# Altium schematic sheet KWATCH_DEV_V0.1.SchDoc(KWATCH_DEV_V0.1)
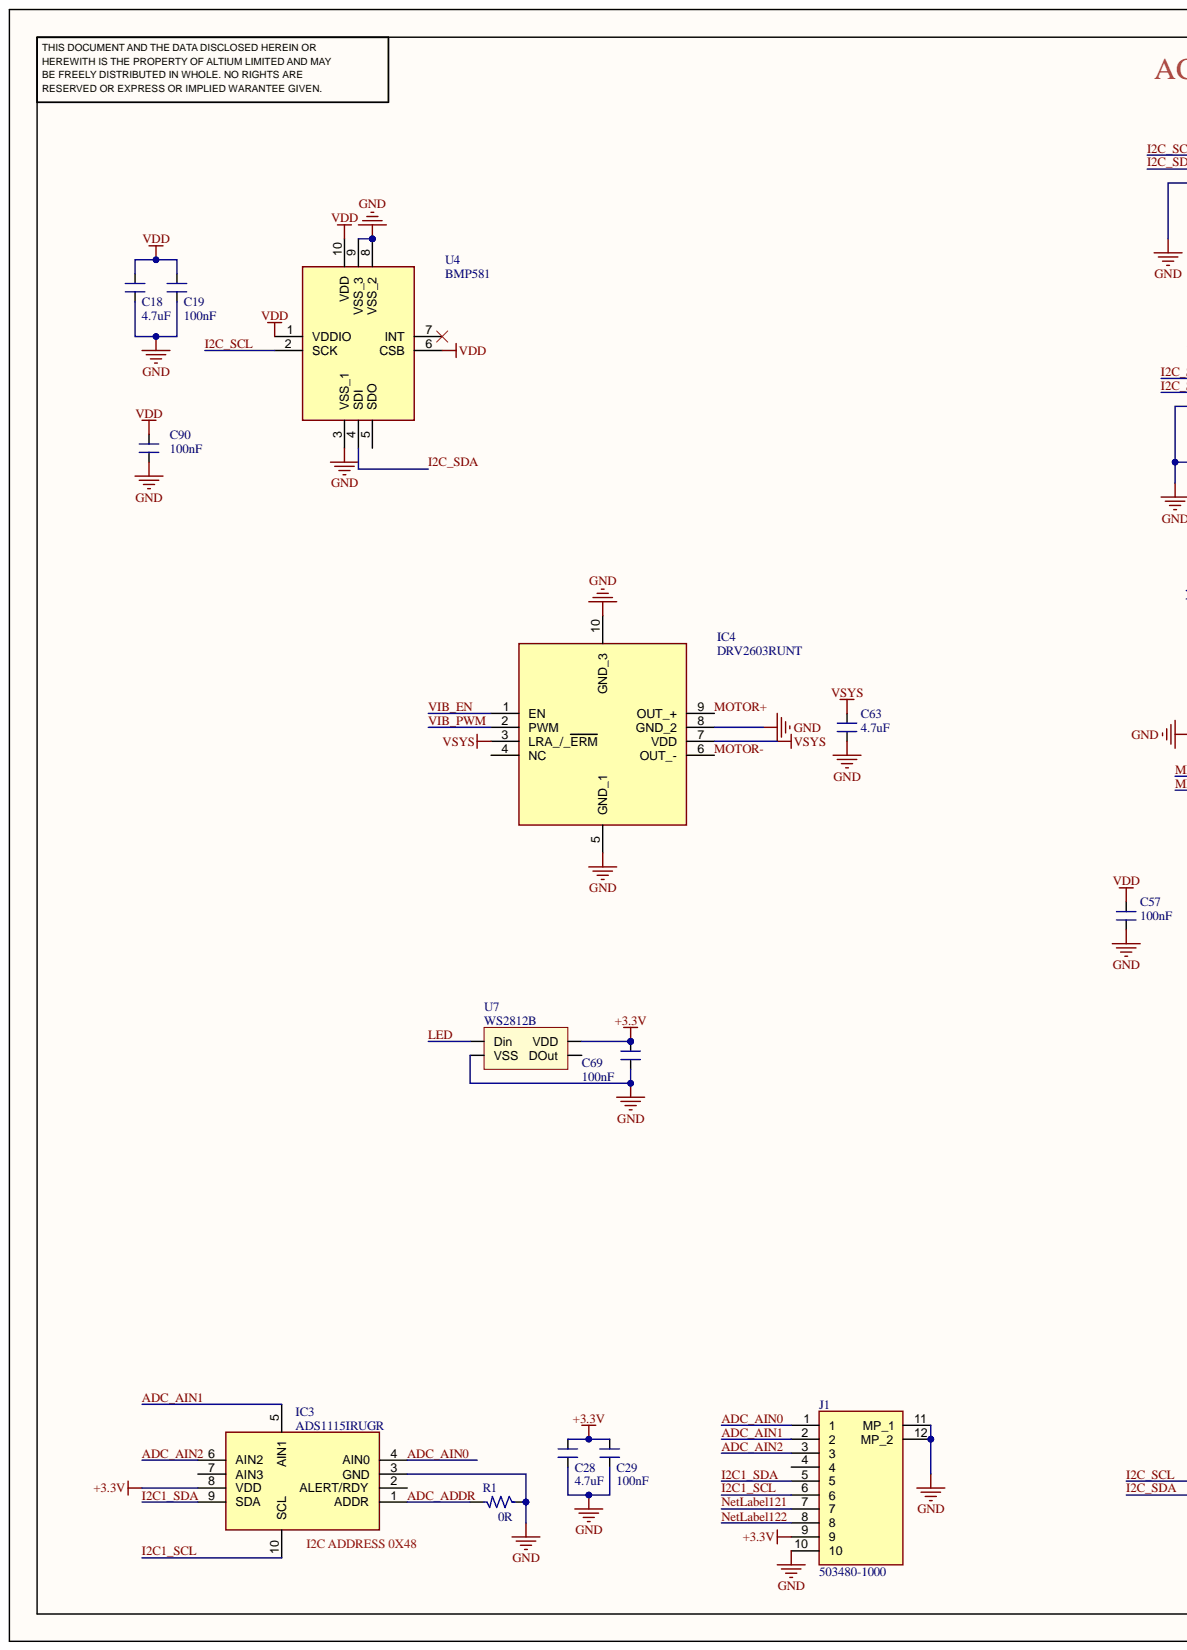
[diagram p0: KWATCH_DEV_V0.1.SchDoc, left]
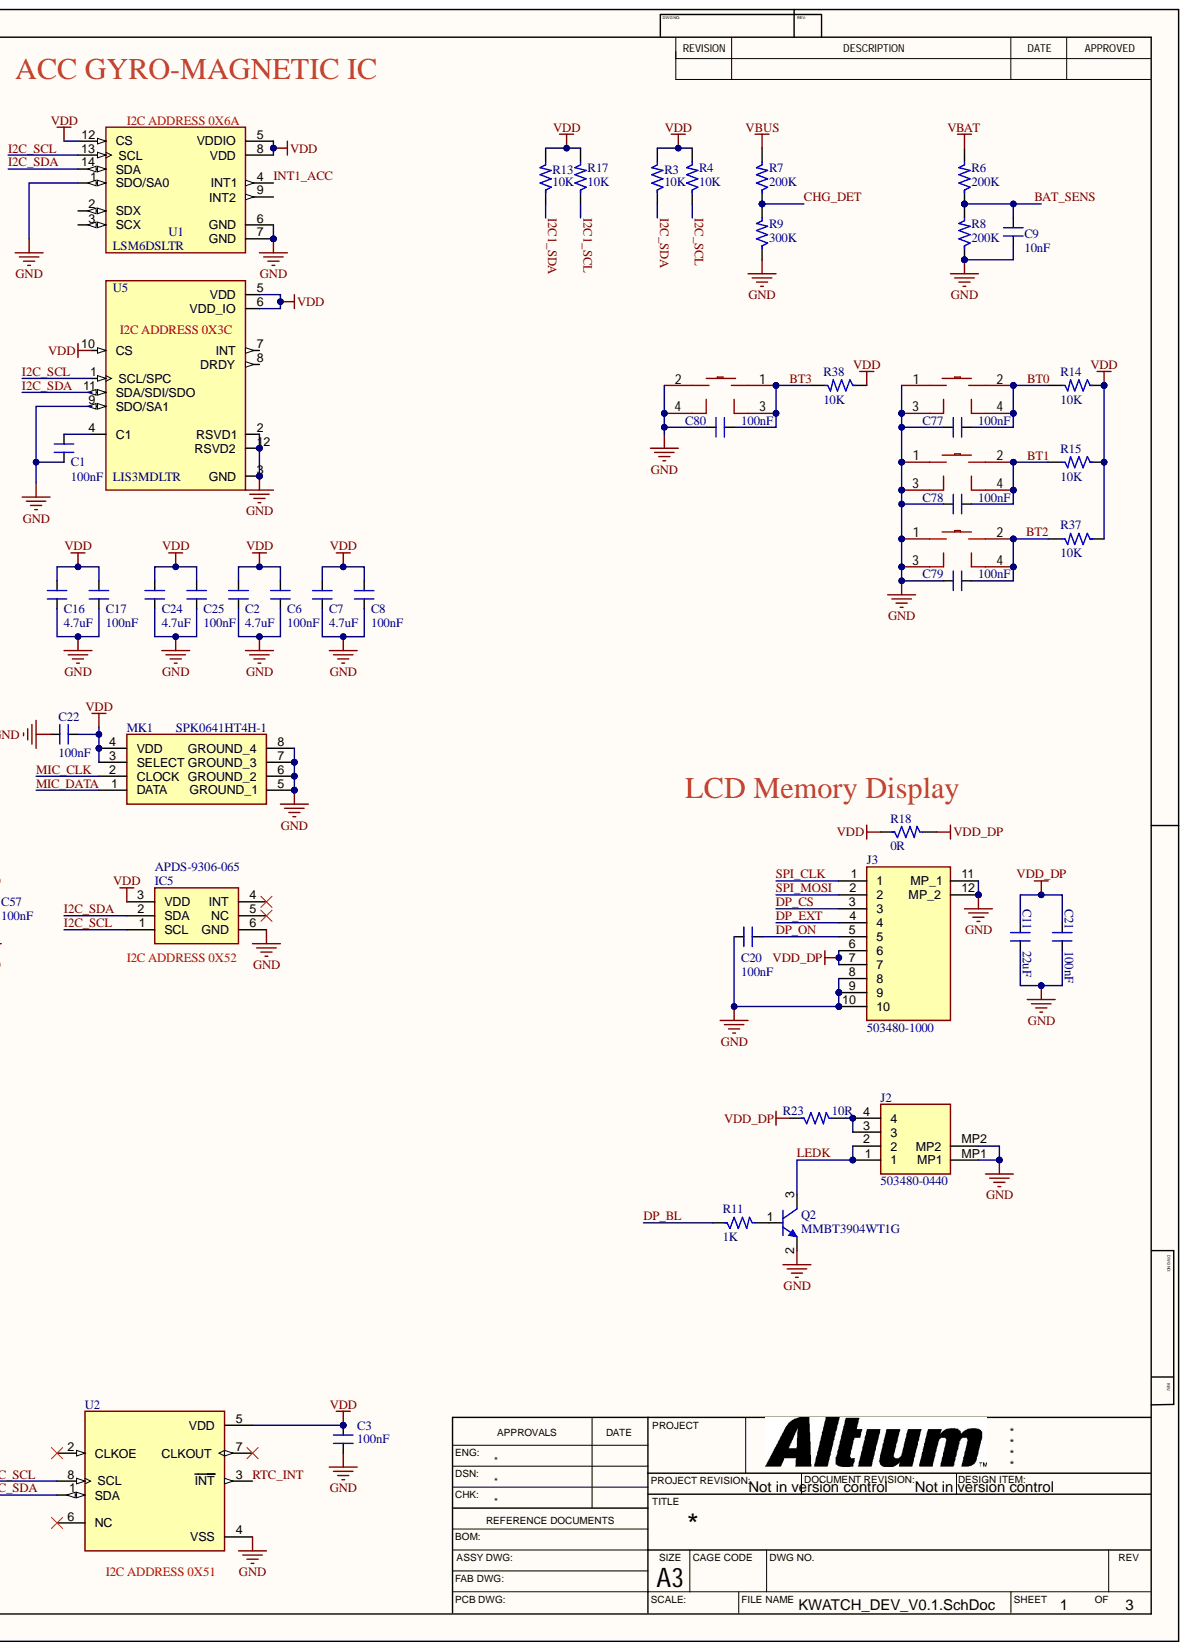
[diagram p1: KWATCH_DEV_V0.1.SchDoc, right]

## Parts
C1  100nF  [CAP_0402]
C2  4.7uF  [CAP_0402]
C3  100nF  [CAP_0402]
C6  100nF  [CAP_0402]
C7  4.7uF  [CAP_0402]
C8  100nF  [CAP_0402]
C9  10nF  [CAP_0402]
C11  22uF  [CAP_0402]
C16  4.7uF  [CAP_0402]
C17  100nF  [CAP_0402]
C18  4.7uF  [CAP_0402]
C19  100nF  [CAP_0402]
C20  100nF  [CAP_0402]
C21  100nF  [CAP_0402]
C22  100nF  [CAP_0402]
C24  4.7uF  [CAP_0402]
C25  100nF  [CAP_0402]
C28  4.7uF  [CAP_0402]
C29  100nF  [CAP_0402]
C57  100nF  [CAP_0402]
C63  4.7uF  [CAP_0402]
C69  100nF  [CAP_0402]
C77  100nF  [CAP_0402]
C78  100nF  [CAP_0402]
C79  100nF  [CAP_0402]
C80  100nF  [CAP_0402]
C90  100nF  [CAP_0402]
IC3  ADS1115IRUGR  [ADS1115IRUGR]
IC4  DRV2603RUNT  [QFN50P200X200X80-10N-D]
IC5  APDS-9306-065  [APDS9306065]
J1  503480-1000  [5034801000]
J2  503480-0440  [5034800440]
J3  503480-1000  [5034801000]
MK1  SPK0641HT4H-1  [SPK0641HT4H1]
Q2  MMBT3904WT1G  [SOT-323-3]
R1  0R  [RES_0402]
R3  10K  [RES_0402]
R4  10K  [RES_0402]
R6  200K  [RES_0402]
R7  200K  [RES_0402]
R8  200K  [RES_0402]
R9  300K  [RES_0402]
R11  1K  [RES_0402]
R13  10K  [RES_0402]
R14  10K  [RES_0402]
R15  10K  [RES_0402]
R17  10K  [RES_0402]
R18  0R  [RES_0402]
R23  10R  [RES_0402]
R37  10K  [RES_0402]
R38  10K  [RES_0402]
SW1  T1C2QR  [KEY-SMD_T1C2QR]  (value not drawn)
SW2  T1C2QR  [KEY-SMD_T1C2QR]  (value not drawn)
SW3  T1C2QR  [KEY-SMD_T1C2QR]  (value not drawn)
SW4  T1C2QR  [KEY-SMD_T1C2QR]  (value not drawn)
U1  LSM6DSLTR  [PQFN50P300X250X86-14N]
U2  RV-8263-C8-32.768KHZ-20PPM-TA-QC  [IC_RV-8263-C8-32.768KHZ-20PPM-TA-QC]  (value not drawn)
U4  BMP581  [BMP581]
U5  LIS3MDLTR  [XDCR_LIS3MDLTR]
U7  WS2812B  [WS2812-2020]

## Nets
+3.3V: C28.2 C29.2 C69.2 IC3.8(VDD) J1.9 U7.1(VDD)
GND: C1.1 C2.1 C3.1 C6.1 C7.1 C8.1 C9.1 C11.1 C16.1 C17.1 C18.1 C19.1 C20.2 C21.1 C22.1 C24.1 C25.1 C28.1 C29.1 C57.1 C63.1 C69.1 C77.1 C78.1 C79.1 C80.1 C90.1 IC3.3(GND) IC4.5(GND_1) IC4.8(GND_2) IC4.10(GND_3) IC5.6(GND) J1.10 J1.11(MP_1) J1.12(MP_2) J2.MP1 J2.MP2 J3.8 J3.9 J3.10 J3.11(MP_1) J3.12(MP_2) MK1.5(GROUND_1) MK1.6(GROUND_2) MK1.7(GROUND_3) MK1.8(GROUND_4) Q2.2 R1.1 R8.1 R9.1 SW1.1 SW1.3 SW2.1 SW2.3 SW3.1 SW3.3 SW4.2 SW4.4 U1.1(SDO/SA0) U1.6(GND) U1.7(GND) U2.4(VSS) U4.3(VSS_1) U4.8(VSS_2) U4.9(VSS_3) U5.2(RSVD1) U5.3(GND) U5.9(SDO/SA1) U5.12(RSVD2) U7.3(VSS)
VBAT: R6.2
VBUS: R7.2
VDD: C2.2 C3.2 C6.2 C7.2 C8.2 C16.2 C17.2 C18.2 C19.2 C22.2 C24.2 C25.2 C57.2 C90.2 IC5.3(VDD) MK1.3(SELECT) MK1.4(VDD) R3.2 R4.2 R13.2 R14.1 R15.1 R17.2 R18.1 R37.1 R38.1 U1.5(VDDIO) U1.8(VDD) U1.12(CS) U2.5(VDD) U4.1(VDDIO) U4.6(CSB) U4.10(VDD) U5.5(VDD) U5.6(VDD_IO) U5.10(CS)
VDD_DP: C11.2 C21.2 J3.6 J3.7 R18.2 R23.1
VSYS: C63.2 IC4.3(LRA_/_ERM) IC4.7(VDD)
ADC_ADDR: IC3.1(ADDR) R1.2
ADC_AIN0: IC3.4(AIN0) J1.1
ADC_AIN1: IC3.5(AIN1) J1.2
ADC_AIN2: IC3.6(AIN2) J1.3
BAT_SENS: C9.2 R6.1 R8.2
BT0: C77.2 R14.2 SW1.2 SW1.4
BT1: C78.2 R15.2 SW2.2 SW2.4
BT2: C79.2 R37.2 SW3.2 SW3.4
BT3: C80.2 R38.2 SW4.1 SW4.3
CHG_DET: R7.1 R9.2
DP_BL: R11.1
DP_CS: J3.3
DP_EXT: J3.4
DP_ON: C20.1 J3.5
I2C1_SCL: IC3.10(SCL) J1.6 R17.1
I2C1_SDA: IC3.9(SDA) J1.5 R13.1
I2C_SCL: IC5.1(SCL) R4.1 U1.13(SCL) U2.8(SCL) U4.2(SCK) U5.1(SCL/SPC)
I2C_SDA: IC5.2(SDA) R3.1 U1.14(SDA) U2.1(SDA) U4.4(SDI) U5.11(SDA/SDI/SDO)
INT1_ACC: U1.4(INT1)
LED: U7.4(Din)
LEDK: J2.1 J2.2 Q2.3
MIC_CLK: MK1.2(CLOCK)
MIC_DATA: MK1.1(DATA)
MOTOR+: IC4.9(OUT_+)
MOTOR-: IC4.6(OUT_-)
NetLabel121: J1.7
NetLabel122: J1.8
RTC_INT: U2.3(INT)
SPI_CLK: J3.1
SPI_MOSI: J3.2
VIB_EN: IC4.1(EN)
VIB_PWM: IC4.2(PWM)
NetC1_2: C1.2 U5.4(C1)
NetJ2_3: J2.3 J2.4 R23.2
NetQ2_1: Q2.1 R11.2

Not connected: IC3 2(ALERT/RDY) 7(AIN3); IC4 4(NC); IC5 4(INT) 5(NC); J1 4; U1 2(SDX) 3(SCX) 9(INT2); U2 2(CLKOE) 6(NC) 7(CLKOUT); U4 5(SDO) 7(INT); U5 7(INT) 8(DRDY); U7 2(DOut)

## Library data of the parts
C1 C3 C6 C8 C17 C19 C22 C25 C29 C57 C69 C77-C80 C90 = CAP_100nF-10V-10%-0402: 0.1µF ±10% 10V Ceramic Capacitor X7R 0402 (1005 Metric); Manufacturer: Yageo; Manufacturer Part Number: CC0402KRX7R6BB104; Case/Package: 0402; Component Kind: Standard; Supplier-1: Digikey; Library Name: EsteemPCB_SOM_Course_schlib.SchLib
C2 C7 C16 C18 C24 C28 C63 = CAP_4.7uF-10V-10%-0402: 4.7µF ±10% 10V Ceramic Capacitor X5R 0402 (1005 Metric); Manufacturer: Samsung Electro-Mechanics; Manufacturer Part Number: CL05A475KP5NRNC; Case/Package: 0402; Component Kind: Standard; Supplier-1: Digikey; Library Name: EsteemPCB_SOM_Course_schlib.SchLib
C9 = CAP_10nF-10V-10%-0402: 10000pF ±10% 10V Ceramic Capacitor X7R 0402 (1005 Metric); Manufacturer: AVX Corporation; Manufacturer Part Number: 0402ZC103KAT2A; Case/Package: 0402; Component Kind: Standard; Supplier-1: Digikey; Library Name: EsteemPCB_SOM_Course_schlib.SchLib
C11 = CAP_22uF-6.3V-20%-0402: 22µF ±20% 6.3V Ceramic Capacitor X5R 0402 (1005 Metric); Manufacturer: Murata Electronics; Manufacturer Part Number: CL05A226MQ5N6J8; Case/Package: 0402; Component Kind: Standard; Supplier-1: Digikey; Library Name: EsteemPCB_SOM_Course_schlib.SchLib
C20 C21 = CAP_100nF-25V-10%-0402: 0.1µF ±10% 25V Ceramic Capacitor X7R 0402 (1005 Metric); Manufacturer: Walsin Technology Corporation; Manufacturer Part Number: 0402B104K250CT; Case/Package: 0402; Component Kind: Standard; Supplier-1: Digikey; Library Name: EsteemPCB_SOM_Course_schlib.SchLib
IC3 = ADS1115IRUGR: Integrated Circuit; Manufacturer_Name: Texas Instruments; Arrow Part Number: ADS1115IRUGR; Arrow Price/Stock: https://www.arrow.com/en/products/ads1115irugr/texas-instruments?utm_currency=USD&region=nac; Component Kind: Standard; Datasheet Link: https://www.ti.com/lit/ds/symlink/ads1115.pdf?ts=1601235703022&ref_url=https%253A%252F%252Fwww.ti.com%252Fproduct%252FADS1115%253FkeyMatch%253DADS1115IRUGR%2526tisearch%253DSearch-EN-everything%2526usecase%253DOPN; Height: 0.4mm; Manufacturer_Part_Number: ADS1115IRUGR; Mouser Part Number: 595-ADS1115IRUGR; Mouser Price/Stock: https://www.mouser.co.uk/ProductDetail/Texas-Instruments/ADS1115IRUGR?qs=IK5e5L0zOXhqw%2F8AHSSbuA%3D%3D
IC4 = DRV2603RUNT: Integrated Circuit; Manufacturer_Name: Texas Instruments; Arrow Part Number: DRV2603RUNT; Arrow Price/Stock: https://www.arrow.com/en/products/drv2603runt/texas-instruments?utm_currency=USD&region=europe; Component Kind: Standard; Datasheet Link: http://www.ti.com/lit/ds/symlink/drv2603.pdf; Height: 0.8mm; Manufacturer_Part_Number: DRV2603RUNT; Mouser Part Number: 595-DRV2603RUNT; Mouser Price/Stock: https://www.mouser.co.uk/ProductDetail/Texas-Instruments/DRV2603RUNT?qs=y0LsnOHuQQ66fXxKAgGo4A%3D%3D
IC5 = APDS-9306-065: Integrated Circuit; Manufacturer_Name: Avago Technologies; Component Kind: Standard; Datasheet Link: A19870331a; Height: 0.75mm; Manufacturer_Part_Number: APDS-9306-065; Mouser Part Number: 630-APDS-9306-065; Mouser Price/Stock: https://www.mouser.co.uk/ProductDetail/Broadcom-Avago/APDS-9306-065?qs=JUmsgfbaopRTut8Cx4p19A%3D%3D
J1 J3 = 503480-1000: Connector; Manufacturer_Name: Molex; Component Kind: Standard; Datasheet Link: https://www.molex.com/pdm_docs/sd/5034801000_sd.pdf; Height: 2.07mm; Manufacturer_Part_Number: 503480-1000; Mouser Part Number: 538-503480-1000; Mouser Price/Stock: https://www.mouser.co.uk/ProductDetail/Molex/503480-1000?qs=bodV9ulq6Gwg5aYhFLiLRA%3D%3D
J2 = 503480-0440: Connector; Manufacturer_Name: Molex; Component Kind: Standard; Datasheet Link: https://www.molex.com/pdm_docs/sd/5034800440_sd.pdf; Height: 2.12mm; Manufacturer_Part_Number: 503480-0440; Mouser Part Number: 538-503480-0440; Mouser Price/Stock: https://www.mouser.co.uk/ProductDetail/Molex/503480-0440?qs=LBbezbu20sggwP%2FAt293Pw%3D%3D
MK1 = SPK0641HT4H-1: Microphone; Manufacturer_Name: Knowles Johanson Manufacturing; Component Kind: Standard; Datasheet Link: https://www.knowles.com/docs/default-source/model-downloads/spk0641ht4h-1-rev-a.pdf; Height: 1.1mm; Manufacturer_Part_Number: SPK0641HT4H-1; Mouser Part Number: 721-SPK0641HT4H-1; Mouser Price/Stock: https://www.mouser.com/Search/Refine.aspx?Keyword=721-SPK0641HT4H-1
Q2 = TRAN-NPN_40V-200mA-SOT323: Bipolar (BJT) Transistor NPN 40V 200mA 300MHz 150mW Surface Mount SC-70-3 (SOT323); Manufacturer: ON Semiconductor; Case/Package: SOT323; Component Kind: Standard; Manufacturer Part Number: MMBT3904WT1G; Supplier-1: Digikey; Library Name: EsteemPCB_SOM_Course_schlib.SchLib
R1 R18 = RES_0R-0.1W-1%-0402: 0 Ohms Jumper 0.1W, 1/10W Chip Resistor 0402 (1005 Metric) Automotive AEC-Q200 Thick Film; Manufacturer: Panasonic Electronic Components; Manufacturer Part Number: ERJ-2GE0R00X; Case/Package: 0402; Component Kind: Standard; Supplier-1: Digikey; Library Name: EsteemPCB_SOM_Course_schlib.SchLib
R3 R4 R13-R15 R17 R37 R38 = RES_10K-0.1W-1%-0402: 10 kOhms ±1% 0.1W, 1/10W Chip Resistor 0402 (1005 Metric) Anti-Sulfur Thin Film; Manufacturer: Vishay Beyschlag/Draloric/BC Components; Manufacturer Part Number: MCS04020C1002FE000; Case/Package: 0402; Component Kind: Standard; Supplier-1: Digikey; Library Name: EsteemPCB_SOM_Course_schlib.SchLib
R6-R8 = RES_200K-0.1W-1%-0402: 200 kOhms ±1% 0.1W, 1/10W Chip Resistor 0402 (1005 Metric) Automotive AEC-Q200 Thick Film; Manufacturer: Panasonic Electronic Components; Manufacturer Part number: ERJ-2RKF2003X; Case/Package: 0402; Component Kind: Standard; Supplier-1: Digikey; Library Name: EsteemPCB_SOM_Course_schlib.SchLib
R9 = RES_200K-0.1W-1%-0402: 300 kOhms ±1% 0.1W, 1/10W Chip Resistor 0402 (1005 Metric) Automotive AEC-Q200 Thick Film; Manufacturer: Panasonic Electronic Components; Manufacturer Part number: ERJ-2RKF2003X; Case/Package: 0402; Component Kind: Standard; Supplier-1: Digikey; Library Name: EsteemPCB_SOM_Course_schlib.SchLib
R11 = RES_1K-0.1W-1%-0402: 1 kOhms ±1% 0.1W, 1/10W Chip Resistor 0402 (1005 Metric) Anti-Sulfur Thin Film; Manufacturer: Vishay Beyschlag/Draloric/BC Components; Manufacturer Part Number: MCS04020C1001FE000; Case/Package: 0402; Component Kind: Standard; Supplier-1: Digikey; Library Name: EsteemPCB_SOM_Course_schlib.SchLib
R23 = RES_0.1R-0.1W-1%-0402: 10 Ohms ±1% 0.1W, 1/10W Chip Resistor 0402 (1005 Metric) Current Sense, Moisture Resistant Thick Film; Manufacturer: Samsung Electro-Mechanics; Manufacturer Part Number: RUT1005FR100CS; Casse/Package: 0402; Component Kind: Standard; Supplier-1: Digikey; Library Name: EsteemPCB_SOM_Course_schlib.SchLib
SW1-SW4 = T1C2QR: Manufacturer: Diptronics(圜达); Actuator Style: Round Button; Add into BOM: yes; Circuit: SPST; Contact Current: 20mA; Voltage Rating: 15V; Component Kind: Standard; Convert to PCB: yes; Datasheet: https://item.szlcsc.com/datasheet/T1C2QR/507368.html; Device: T1C2QR; JLCPCB Part Class: Extended Part; LCSC Part Name: 侧按贴片; Library Name: SamacSys.SchLib; Life: -; Manufacturer Part: T1C2QR; Mounting Type: Surface Mount, Right Angle; PCB3D: KEY-SMD_T1C2QR; Supplier: LCSC; Supplier Footprint: SMD,2x2.8mm; Supplier Part: C495948; Symbol: T1C2QR; With Lamp: -
U1 = LSM6DSLTR: Accelerometer, Gyroscope, Temperature, 6 Axis Sensor IÂ²C, SPI Output; MF: STMicroelectronics; Availability: Unavailable; Component Kind: Standard; Library Name: SamacSys.SchLib; MP: LSM6DSLTR; Package: LGA-14 STMicroelectronics; Price: None; SnapEDA_Link: https://www.snapeda.com/parts/LSM6DSLTR/STMicroelectronics/view-part/550517/?ref=snap
U2 = RV-8263-C8-32.768KHZ-20PPM-TA-QC: Real-Time Clock Module in 1.2 x 2.0 mm package; MF: Micro Crystal; Check_prices: https://www.snapeda.com/parts/RV-8263-C8-32.768KHZ-20PPM-TA-QC/Micro+Crystal/view-part/?ref=eda; Component Kind: Standard; Library Name: SamacSys.SchLib; MP: RV-8263-C8-32.768KHZ-20PPM-TA-QC; Package: QFN-8 Micro Crystal; SnapEDA_Link: https://www.snapeda.com/parts/RV-8263-C8-32.768KHZ-20PPM-TA-QC/Micro+Crystal/view-part/?ref=snap
U4 = BMP581: Undefined or Miscellaneous; Manufacturer_Name: BOSCH; Arrow Part Number: BMP581; Arrow Price/Stock: https://www.arrow.com/en/products/bmp581/bosch-sensortec?utm_currency=USD&region=nac; Component Kind: Standard; Datasheet Link: https://www.bosch-sensortec.com/media/boschsensortec/downloads/datasheets/bst-bmp581-ds004.pdf; Height: 0.8mm; Manufacturer_Part_Number: BMP581; Mouser Part Number: 262-BMP581; Mouser Price/Stock: https://www.mouser.co.uk/ProductDetail/Bosch-Sensortec/BMP581?qs=Li%252BoUPsLEntPL9tlFmcgXg%3D%3D
U5 = LIS3MDLTR: Magnetoresistive Sensor X, Y, Z Axis 12-LGA _2x2_; MF: STMicroelectronics; Availability: Unavailable; Component Kind: Standard; Library Name: SamacSys.SchLib; MP: LIS3MDLTR; Package: VFLGA-12 STMicroelectronics; Price: None; SnapEDA_Link: https://www.snapeda.com/parts/LIS3MDLTR/STMicroelectronics/view-part/382740/?ref=snap
U7 = WS2812B: Component Kind: Standard; Library Name: SamacSys.SchLib
